# Altium schematic sheet 10ethernet100.SchDoc
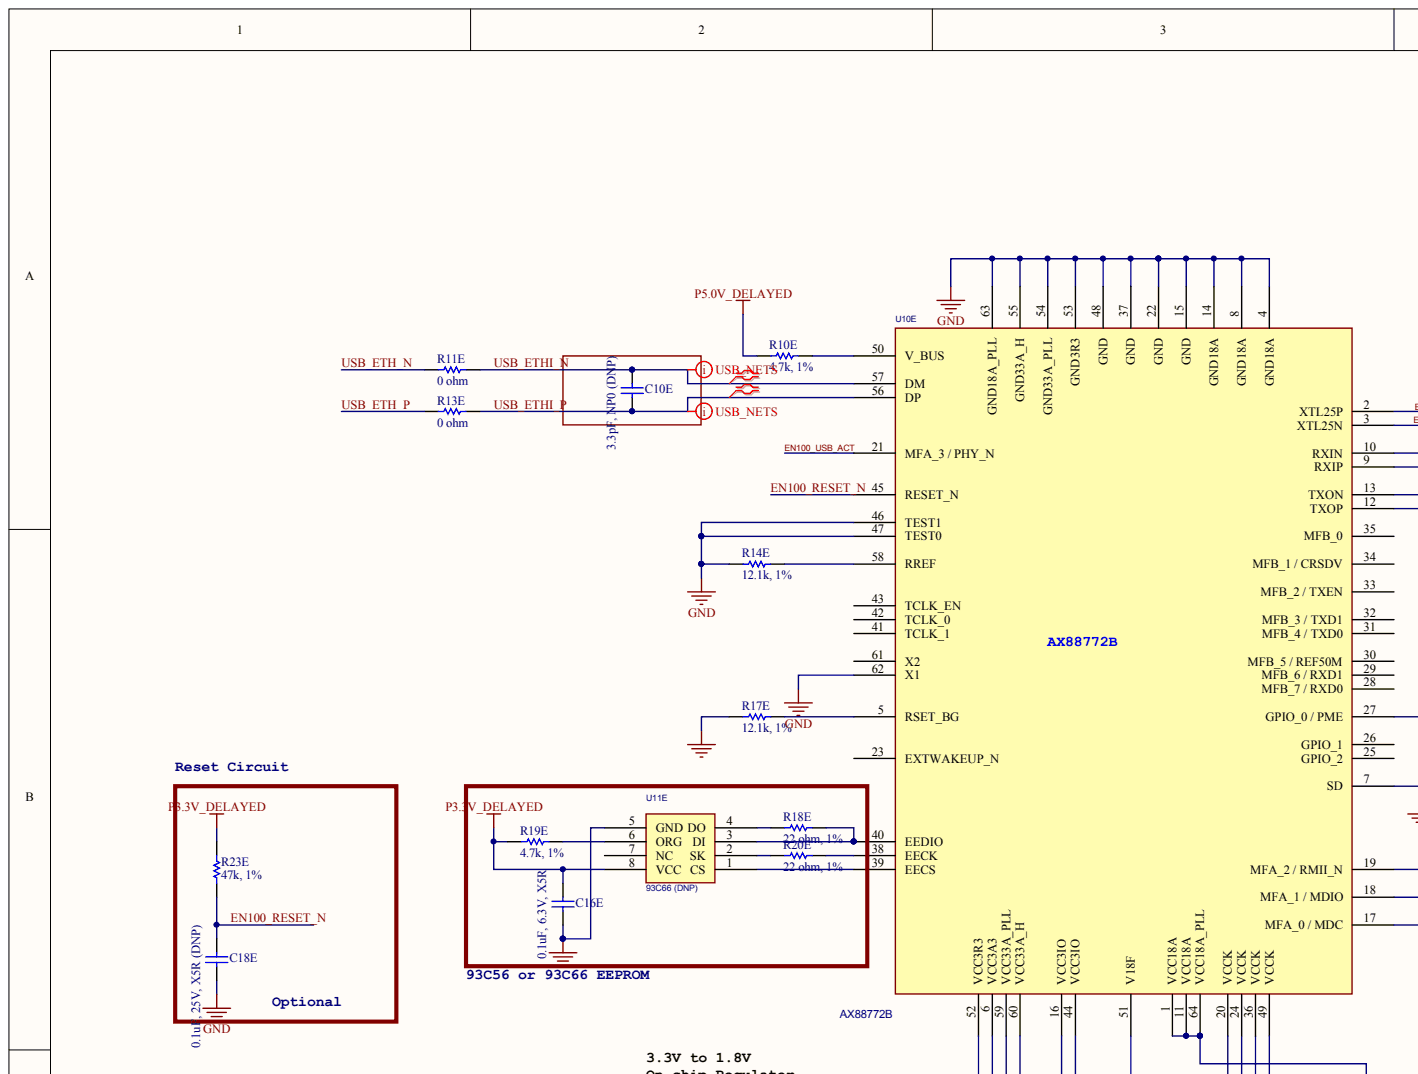
[diagram p0: 10ethernet100.SchDoc, top left]
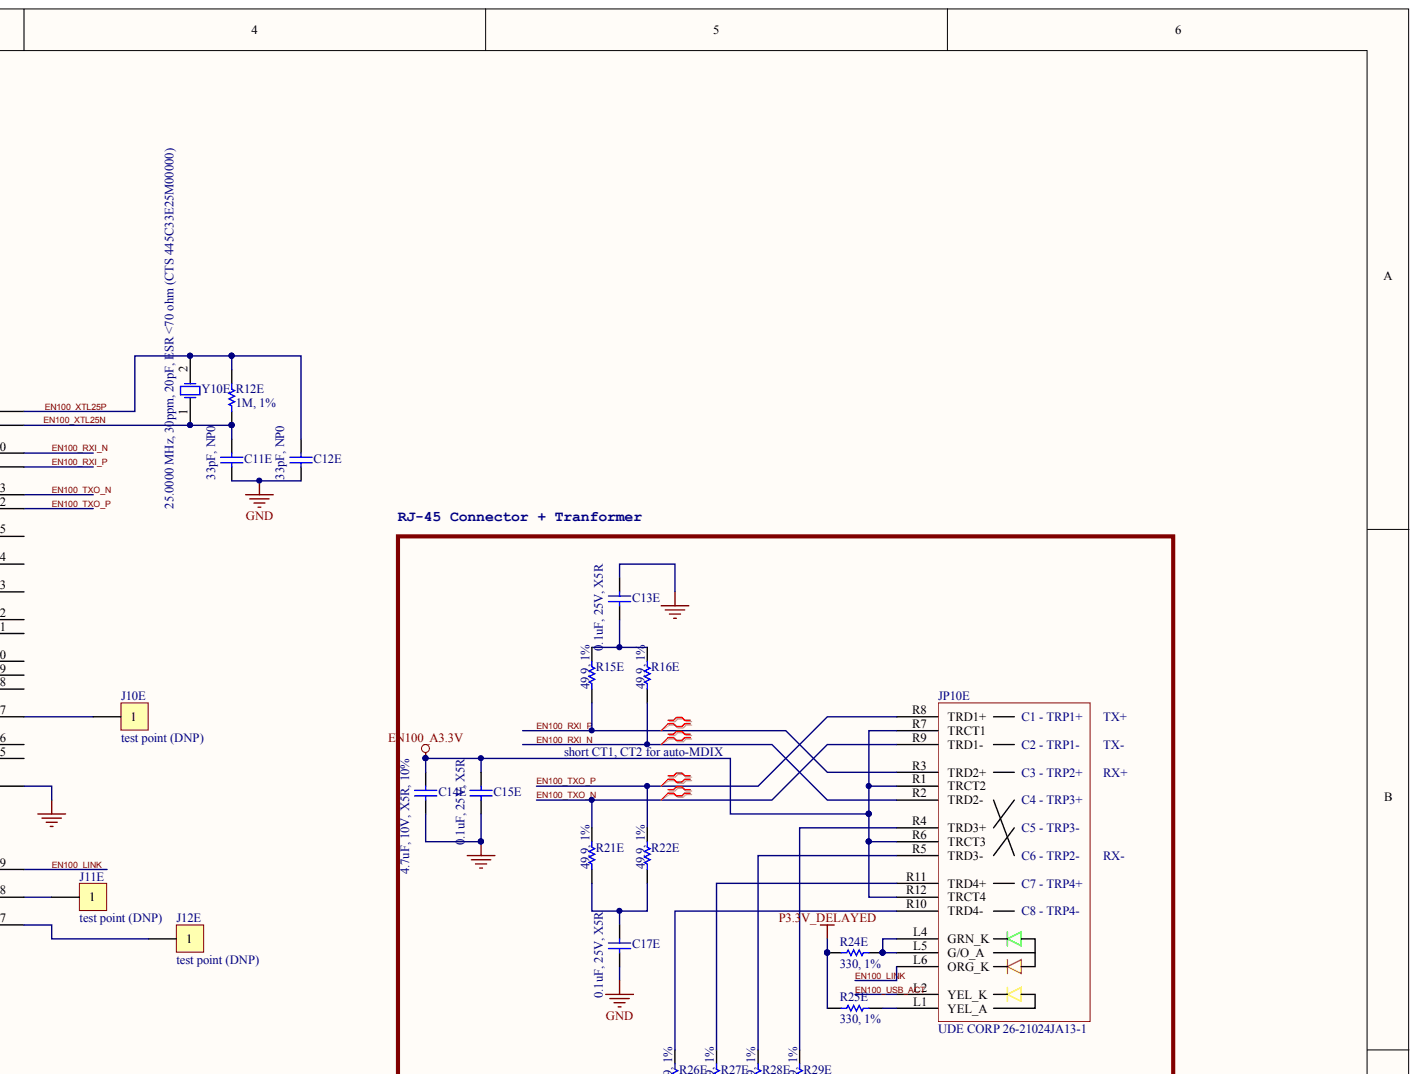
[diagram p1: 10ethernet100.SchDoc, top right]
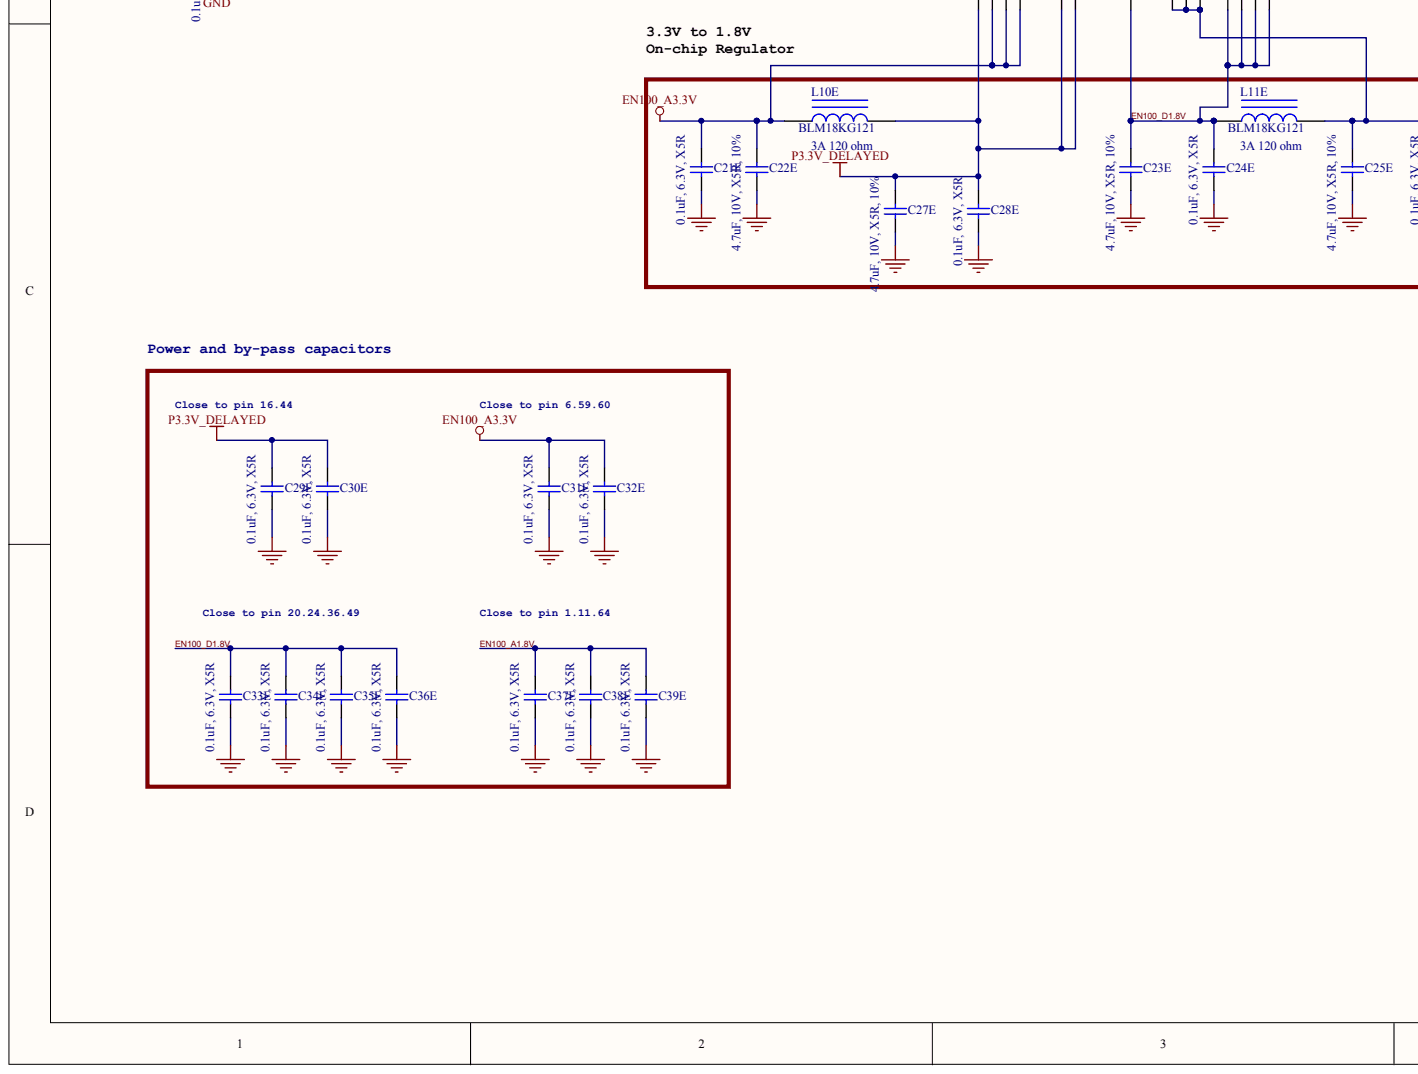
[diagram p2: 10ethernet100.SchDoc, bottom left]
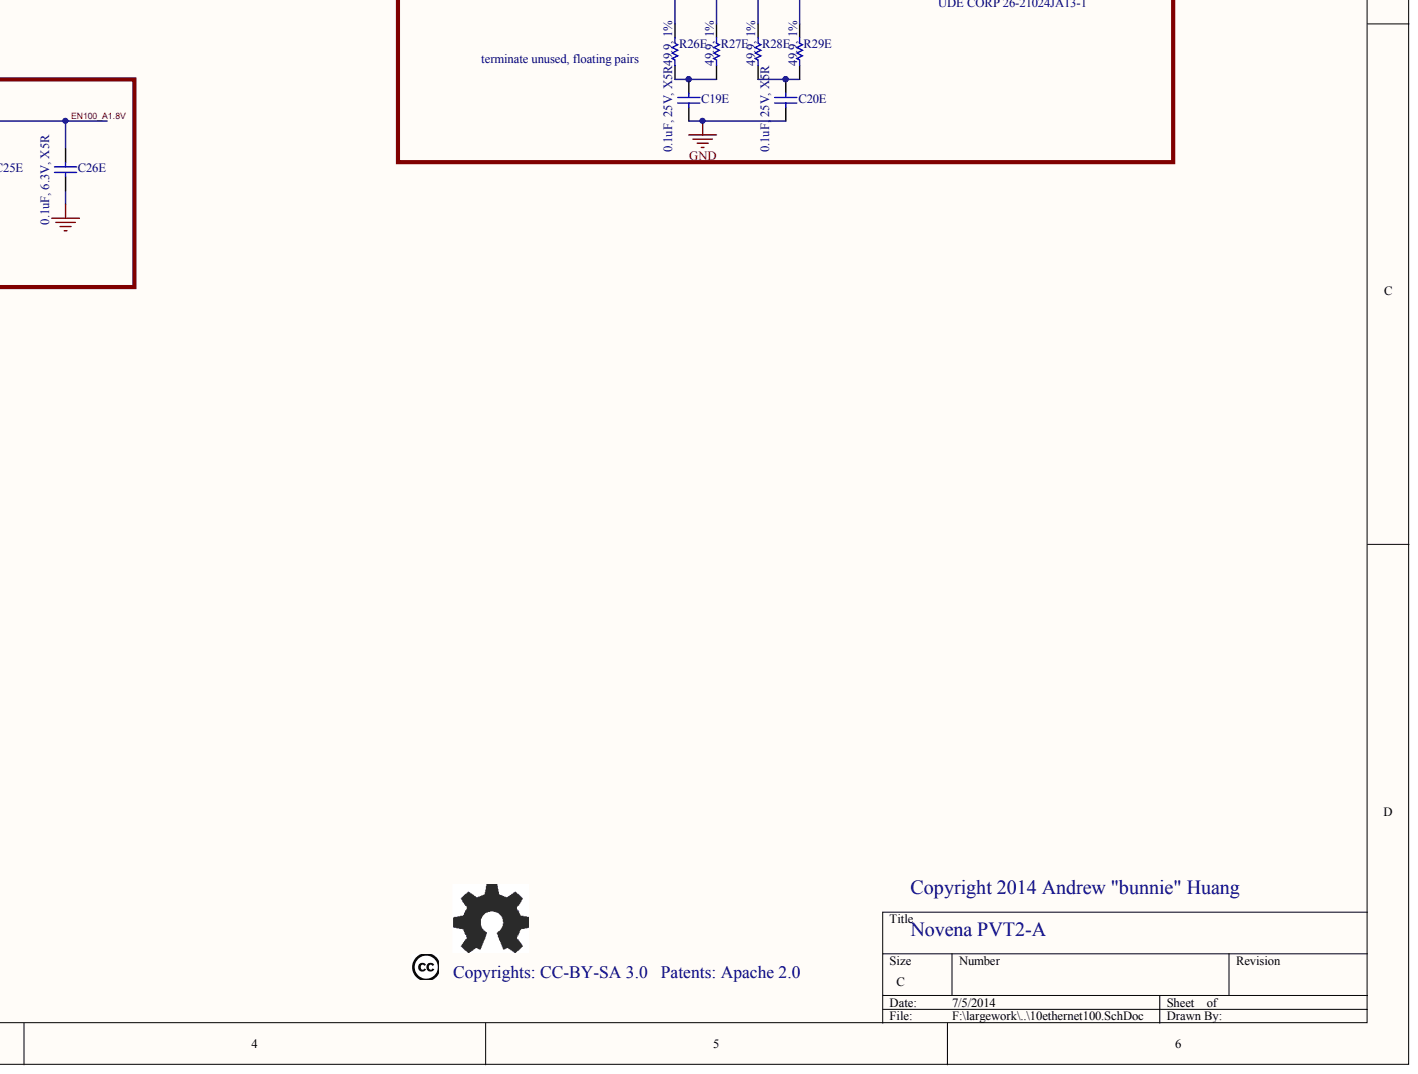
[diagram p3: 10ethernet100.SchDoc, bottom right]

## Parts
C10E  3.3pF, NP0 (DNP)
C11E  33pF, NP0
C12E  33pF, NP0
C13E  0.1uF, 25V, X5R
C14E  4.7uF, 10V, X5R, 10%
C15E  0.1uF, 25V, X5R
C16E  0.1uF, 6.3V, X5R
C17E  0.1uF, 25V, X5R
C18E  0.1uF, 25V, X5R (DNP)
C19E  0.1uF, 25V, X5R
C20E  0.1uF, 25V, X5R
C21E  0.1uF, 6.3V, X5R
C22E  4.7uF, 10V, X5R, 10%
C23E  4.7uF, 10V, X5R, 10%
C24E  0.1uF, 6.3V, X5R
C25E  4.7uF, 10V, X5R, 10%
C26E  0.1uF, 6.3V, X5R
C27E  4.7uF, 10V, X5R, 10%
C28E  0.1uF, 6.3V, X5R
C29E  0.1uF, 6.3V, X5R
C30E  0.1uF, 6.3V, X5R
C31E  0.1uF, 6.3V, X5R
C32E  0.1uF, 6.3V, X5R
C33E  0.1uF, 6.3V, X5R
C34E  0.1uF, 6.3V, X5R
C35E  0.1uF, 6.3V, X5R
C36E  0.1uF, 6.3V, X5R
C37E  0.1uF, 6.3V, X5R
C38E  0.1uF, 6.3V, X5R
C39E  0.1uF, 6.3V, X5R
J10E  test point (DNP)
J11E  test point (DNP)
J12E  test point (DNP)
JP10E  UDE CORP 26-21024JA13-1
L10E  BLM18KG121  value 3A 120 ohm
L11E  BLM18KG121  value 3A 120 ohm
R10E  4.7k, 1%
R11E  0 ohm
R12E  1M, 1%
R13E  0 ohm
R14E  12.1k, 1%
R15E  49.9, 1%
R16E  49.9, 1%
R17E  12.1k, 1%
R18E  22 ohm, 1%
R19E  4.7k, 1%
R20E  22 ohm, 1%
R21E  49.9, 1%
R22E  49.9, 1%
R23E  47k, 1%
R24E  330, 1%
R25E  330, 1%
R26E  49.9, 1%
R27E  49.9, 1%
R28E  49.9, 1%
R29E  49.9, 1%
U10E  AX88772B
U11E  93C66 (DNP)
Y10E  25.0000 MHz, 30ppm, 20pF, ESR <70 ohm (CTS 445C33E25M00000)

## Nets
EN100_A3.3V: C14E.1 C15E.1 C21E.1 C22E.1 C31E.1 C32E.1 JP10E.R1(TRCT2) JP10E.R6(TRCT3) JP10E.R7(TRCT1) JP10E.R12(TRCT4) L10E.1 U10E.6(VCC3A3) U10E.59(VCC33A_PLL) U10E.60(VCC33A_H)
GND: C11E.2 C12E.2 C13E.1 C14E.2 C15E.2 C16E.2 C17E.2 C18E.2 C19E.2 C20E.2 C21E.2 C22E.2 C23E.2 C24E.2 C25E.2 C26E.2 C27E.2 C28E.2 C29E.2 C30E.2 C31E.2 C32E.2 C33E.2 C34E.2 C35E.2 C36E.2 C37E.2 C38E.2 C39E.2 R14E.1 R17E.1 U10E.4(GND18A) U10E.7(SD) U10E.8(GND18A) U10E.14(GND18A) U10E.15(GND) U10E.22(GND) U10E.37(GND) U10E.46(TEST1) U10E.47(TEST0) U10E.48(GND) U10E.53(GND3R3) U10E.54(GND33A_PLL) U10E.55(GND33A_H) U10E.62(X1) U10E.63(GND18A_PLL) U11E.5(GND)
P3.3V_DELAYED: C16E.1 C27E.1 C28E.1 C29E.1 C30E.1 L10E.2 R19E.1 R23E.1 R24E.1 R25E.1 U10E.16(VCC3IO) U10E.44(VCC3IO) U10E.52(VCC3R3) U11E.8(VCC)
P5.0V_DELAYED: R10E.1
EN100_A1.8V: C25E.1 C26E.1 C37E.1 C38E.1 C39E.1 L11E.2 U10E.1(VCC18A) U10E.11(VCC18A) U10E.64(VCC18A_PLL)
EN100_D1.8V: C23E.1 C24E.1 C33E.1 C34E.1 C35E.1 C36E.1 L11E.1 U10E.20(VCCK) U10E.24(VCCK) U10E.36(VCCK) U10E.49(VCCK) U10E.51(V18F)
EN100_LINK: JP10E.L6(ORG_K) U10E.19(MFA_2_/_RMII_N)
EN100_RESET_N: C18E.1 R23E.2 U10E.45(RESET_N)
EN100_RXI_N: JP10E.R2(TRD2-) R16E.1 U10E.10(RXIN)
EN100_RXI_P: JP10E.R3(TRD2+) R15E.1 U10E.9(RXIP)
EN100_TXO_N: JP10E.R9(TRD1-) R21E.2 U10E.13(TXON)
EN100_TXO_P: JP10E.R8(TRD1+) R22E.2 U10E.12(TXOP)
EN100_USB_ACT: JP10E.L2(YEL_K) U10E.21(MFA_3_/_PHY_N)
EN100_XTL25N: C11E.1 R12E.1 U10E.3(XTL25N) Y10E.1
EN100_XTL25P: C12E.1 R12E.2 U10E.2(XTL25P) Y10E.2
USB_ETH_N: R11E.1
USB_ETH_P: R13E.1
USB_ETHI_N: C10E.1 R11E.2 U10E.57(DM)
USB_ETHI_P: C10E.2 R13E.2 U10E.56(DP)
NetC13E_2: C13E.2 R15E.2 R16E.2
NetC17E_1: C17E.1 R21E.1 R22E.1
NetC19E_1: C19E.1 R26E.1 R27E.1
NetC20E_1: C20E.1 R28E.1 R29E.1
NetJ10E_1: J10E.1 U10E.27(GPIO_0_/_PME)
NetJ11E_1: J11E.1 U10E.18(MFA_1_/_MDIO)
NetJ12E_1: J12E.1 U10E.17(MFA_0_/_MDC)
NetJP10E_L1: JP10E.L1(YEL_A) R25E.2
NetJP10E_L4: JP10E.L4(GRN_K) JP10E.L5(G/O_A) R24E.2
NetJP10E_R4: JP10E.R4(TRD3+) R29E.2
NetJP10E_R5: JP10E.R5(TRD3-) R28E.2
NetJP10E_R10: JP10E.R10(TRD4-) R26E.2
NetJP10E_R11: JP10E.R11(TRD4+) R27E.2
NetR10E_2: R10E.2 U10E.50(V_BUS)
NetR14E_2: R14E.2 U10E.58(RREF)
NetR17E_2: R17E.2 U10E.5(RSET_BG)
NetR18E_1: R18E.1 U11E.4(DO)
NetR18E_2: R18E.2 U10E.40(EEDIO) U11E.3(DI)
NetR19E_2: R19E.2 U11E.6(ORG)
NetR20E_1: R20E.1 U11E.2(SK)
NetR20E_2: R20E.2 U10E.38(EECK)
NetU10E_39: U10E.39(EECS) U11E.1(CS)

Not connected: U10E 23(EXTWAKEUP_N) 25(GPIO_2) 26(GPIO_1) 28(MFB_7_/_RXD0) 29(MFB_6_/_RXD1) 30(MFB_5_/_REF50M) 31(MFB_4_/_TXD0) 32(MFB_3_/_TXD1) 33(MFB_2_/_TXEN) 34(MFB_1_/_CRSDV) 35(MFB_0) 41(TCLK_1) 42(TCLK_0) 43(TCLK_EN) 61(X2); U11E 7(NC)

## Library data of the parts
R10E R11E R12E R13E R14E R15E R16E R17E R18E R19E R20E R21E R22E R23E R24E R25E R26E R27E R28E R29E = (no symbol name): Value: 100k; Published: 8-Jun-2000; LatestRevisionDate: 17-Jul-2002; LatestRevisionNote: Re-released for DXP Platform.; PackageReference: AXIAL-0.3; Publisher: Altium Limited
C10E C11E C12E C13E C14E C15E C16E C17E C18E C19E C20E C21E C22E C23E C24E C25E C26E C27E C28E C29E C30E C31E C32E C33E C34E C35E C36E C37E C38E C39E J10E J11E J12E JP10E L10E L11E U10E U11E Y10E = (no symbol name)
  C10E C11E C12E C13E C14E C15E C16E C17E C18E C19E C20E C21E C22E C23E C24E C25E C26E C27E C28E C29E C30E C31E C32E C33E C34E C35E C36E C37E C38E C39E: Published: 8-Jun-2000; LatestRevisionDate: 17-Jul-2002; LatestRevisionNote: Re-released for DXP Platform.; PackageReference: C1206
  L10E L11E: Published: 24-Mar-1999; LatestRevisionDate: 17-Jul-2002; LatestRevisionNote: Re-released for DXP Platform.; PackageReference: AXIAL-0.9
  Y10E: Published: 8-Jun-2000; LatestRevisionDate: 17-Jul-2002; LatestRevisionNote: Re-released for DXP Platform.; PackageReference: R38
  C10E C11E C12E C13E C14E C15E C16E C17E C18E C19E C20E C21E C22E C23E C24E C25E C26E C27E C28E C29E C30E C31E C32E C33E C34E C35E C36E C37E C38E C39E L10E L11E Y10E: Publisher: Altium Limited
